# Altium schematic sheet Power.SchDoc(Power)
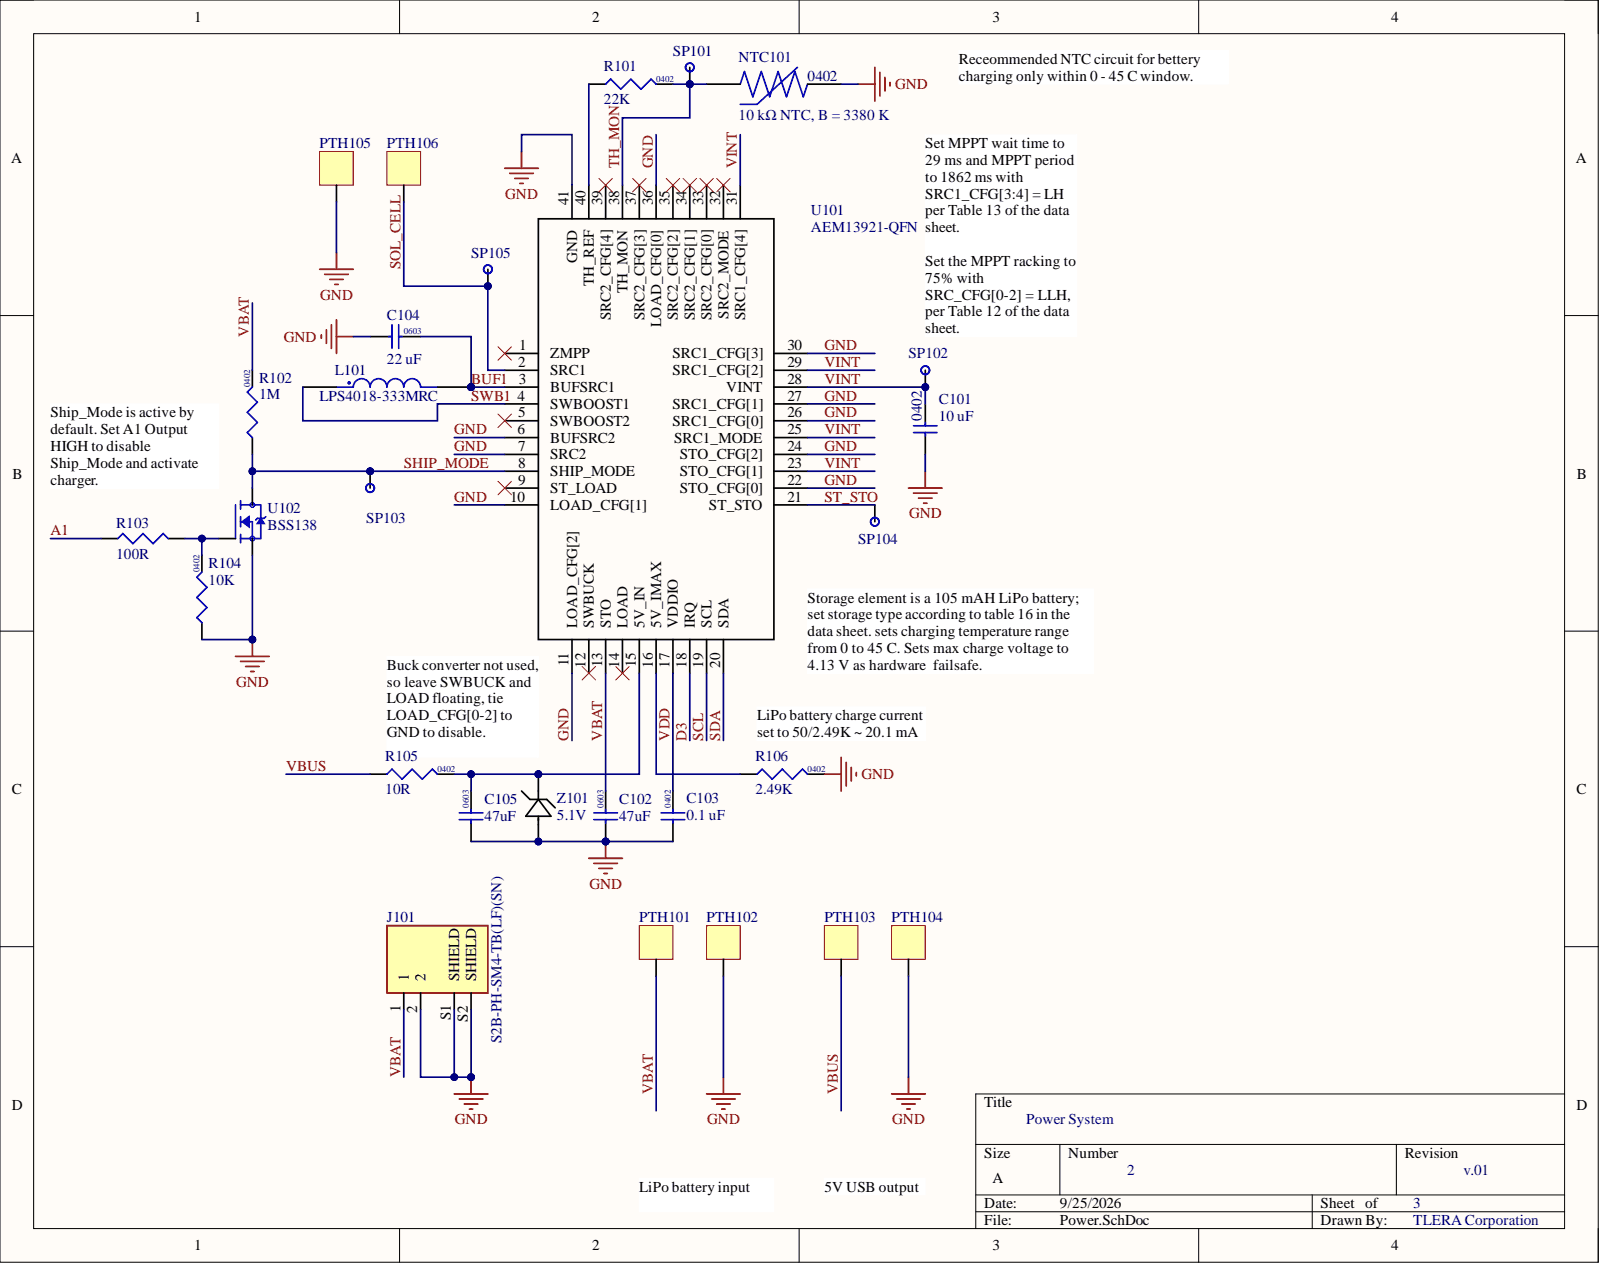
[diagram p0: Power.SchDoc]

## Parts
C101  10 uF  Size Code: 0402
C102  47uF
C103  0.1 uF  Size Code: 0402
C104  22 uF
C105  47uF
J101  S2B-PH-SM4-TB(LF)(SN)
L101  LPS4018-333MRC
NTC101  10 kΩ NTC, B = 3380 K
PTH101  PTH_2mm  (value not drawn)
PTH102  PTH_2mm  (value not drawn)
PTH103  PTH_2mm  (value not drawn)
PTH104  PTH_2mm  (value not drawn)
PTH105  PTH_2mm  (value not drawn)
PTH106  PTH_2mm  (value not drawn)
R101  22K
R102  1M  Size Code: 0402
R103  100R
R104  10K  Size Code: 0402
R105  10R
R106  2.49K
SP101  1 mm Test Pad  (value not drawn)
SP102  1 mm Test Pad  (value not drawn)
SP103  1 mm Test Pad  (value not drawn)
SP104  1 mm Test Pad  (value not drawn)
SP105  1 mm Test Pad  (value not drawn)
U101  AEM13921-QFN
U102  BSS138
Z101  5.1V

## Nets
A1: R103.1
BUF1: C104.2 L101.2 U101.3(BUFSRC1)
D3: U101.18(IRQ)
GND: C101.1 C102.1 C103.1 C104.1 C105.1 J101.2 J101.S1(SHIELD) J101.S2(SHIELD) NTC101.2 PTH102.1 PTH104.1 PTH105.1 R104.1 R106.2 U101.6(BUFSRC2) U101.7(SRC2) U101.10(LOAD_CFG[1]) U101.11(LOAD_CFG[2]) U101.22(STO_CFG[0]) U101.24(STO_CFG[2]) U101.26(SRC1_CFG[0]) U101.27(SRC1_CFG[1]) U101.30(SRC1_CFG[3]) U101.36(LOAD_CFG[0]) U101.41(GND) U102.2 Z101.2
SCL: U101.19(SCL)
SDA: U101.20(SDA)
SHIP_MODE: R102.1 SP103.1 U101.8(SHIP_MODE) U102.3
SOL_CELL: PTH106.1 SP105.1 U101.2(SRC1)
ST_STO: SP104.1 U101.21(ST_STO)
SWB1: L101.1 U101.4(SWBOOST1)
TH_MON: NTC101.1 R101.2 SP101.1 U101.38(TH_MON)
VBAT: C102.2 J101.1 PTH101.1 R102.2 U101.13(STO)
VBUS: PTH103.1 R105.1
VDD: C103.2 U101.17(VDDIO)
VINT: C101.2 SP102.1 U101.23(STO_CFG[1]) U101.25(SRC1_MODE) U101.28(VINT) U101.29(SRC1_CFG[2]) U101.31(SRC1_CFG[4])
NetC105_2: C105.2 R105.2 U101.15(5V_IN) Z101.1
NetR101_1: R101.1 U101.40(TH_REF)
NetR103_2: R103.2 R104.2 U102.1
NetR106_1: R106.1 U101.16(5V_IMAX)

Not connected: U101 1(ZMPP) 5(SWBOOST2) 9(ST_LOAD) 12(SWBUCK) 14(LOAD) 32(SRC2_MODE) 33(SRC2_CFG[0]) 34(SRC2_CFG[1]) 35(SRC2_CFG[2]) 37(SRC2_CFG[3]) 39(SRC2_CFG[4])

## Library data of the parts
C101 = CL05A106MQ5NUNC: 0402 10uF Ceramic Capacitor, Multilayer, Ceramic, 6.3V, 20% +Tol, 20% -Tol, X5R, 15% TC, 10uF, Surface Mount, 0402; Manufacturer: Samsung; Multilayer: Yes; Capacitance: 10uF; Capacitor Type: Ceramic; Category: Ceramic Capacitors; Component Kind: Standard; ComponentLink1Description: https://datasheet.ciiva.com:443/pdfs/VipMasterIC/IC/SEMS/SEMS-S-A0008521219/SEMS-S-A0008521300-1.pdf?src-supplier=IHS+Markit; ComponentLink1URL: https://datasheet.ciiva.com:443/pdfs/VipMasterIC/IC/SEMS/SEMS-S-A0008521219/SEMS-S-A0008521300-1.pdf?src-supplier=IHS+Markit; ComponentLink2Description: https://datasheet.ciiva.com/16437/cl05a106mq5nunc-16437356.pdf?src-supplier=Future+Electronics; ComponentLink2URL: https://datasheet.ciiva.com/16437/cl05a106mq5nunc-16437356.pdf?src-supplier=Future+Electronics; ComponentLink3Description: https://datasheet.ciiva.com/26877/getdatasheetpartid-355289-26877841.pdf?src-supplier=Verical; ComponentLink3URL: https://datasheet.ciiva.com/26877/getdatasheetpartid-355289-26877841.pdf?src-supplier=Verical; Dielectric Material: Ceramic; Height: 0.7mm; JESD-609 Code: e3; Length: 1mm; Library Name: Sensors.SchLib; Manufacturer Part Number: CL05A106MQ5NUNC; Max Operating Temperature: 85°C; Min Operating Temperature: -55°C; Mount: Surface Mount; Negative Tolerance: 20%; Number of Pins: 2; Package Shape: Rectangular; Package Style: SMTMeter; Packaging: Tape and Reel; Positive Tolerance: 20%; Pricing 1: 1=0.26000, 10=0.17600, 50=0.10540, 100=0.08900, 500=0.06086, 1000=0.05384, 2500=0.05150, 5000=0.04682 (USD); Rated DC Voltage (URdc): 6.3V; REACH SVHC: Yes; RoHS: Yes; RoHS Compliant: Yes; Stock 1: 9272723; Supplier 1: Digi-Key; Supplier Part Number 1: 1276-1451-1-ND; Temperature Characteristics Code: X5R; Terminal Finish: Nickel; Termination: Wraparound; Width: 0.5mm
C102 C105 = GRM188R60J476ME15D: 0603 47 µF ±20% 6.3V Ceramic Capacitor X5R 0603 (1608 Metric); Component Kind: Standard; Library Name: Sensors.SchLib; Supplier 1: Avnet; Supplier Part Number 1: GRM188R60J476ME15D
C103 = CL05B104KO5NNNC: 0402 0.1 uF Ceramic Capacitor, Multilayer, Ceramic, 16V, 10% +Tol, 10% -Tol, X7R, 15% TC, 0.1uF, Surface Mount, 0402; Manufacturer: Samsung; Capacitance: 100nF; Multilayer: Yes; Capacitor Type: Ceramic; Category: Ceramic Capacitors; Component Kind: Standard; ComponentLink1Description: https://datasheet.ciiva.com:443/pdfs/VipMasterIC/IC/SEMS/SEMS-S-A0008384515/SEMS-S-A0008872921-1.pdf?src-supplier=IHS+Markit; ComponentLink1URL: https://datasheet.ciiva.com:443/pdfs/VipMasterIC/IC/SEMS/SEMS-S-A0008384515/SEMS-S-A0008872921-1.pdf?src-supplier=IHS+Markit; ComponentLink2Description: https://datasheet.ciiva.com/26920/getdatasheetpartid-359683-26920169.pdf?src-supplier=Verical; ComponentLink2URL: https://datasheet.ciiva.com/26920/getdatasheetpartid-359683-26920169.pdf?src-supplier=Verical; ComponentLink3Description: https://datasheet.ciiva.com/29198/458718-da-01-en-vs-kondensator-0402-29198423.pdf?src-supplier=Conrad; ComponentLink3URL: https://datasheet.ciiva.com/29198/458718-da-01-en-vs-kondensator-0402-29198423.pdf?src-supplier=Conrad; ComponentLink4Description: https://datasheet.ciiva.com/16208/cl05b104kq5nnnc-16208343.pdf?src-supplier=Future+Electronics; ComponentLink4URL: https://datasheet.ciiva.com/16208/cl05b104kq5nnnc-16208343.pdf?src-supplier=Future+Electronics; ComponentLink5Description: https://datasheet.ciiva.com/26309/mlcc-catalogdownload2013-eng-26309003.pdf?src-supplier=Distrelec; ComponentLink5URL: https://datasheet.ciiva.com/26309/mlcc-catalogdownload2013-eng-26309003.pdf?src-supplier=Distrelec; Dielectric Material: Ceramic; Height: 0.55mm; JESD-609 Code: e3; Length: 1mm; Library Name: Sensors.SchLib; Manufacturer Part Number: CL05B104KO5NNNC; Max Operating Temperature: 125°C; Min Operating Temperature: -55°C; Mount: Surface Mount; Negative Tolerance: 10%; Number of Pins: 2; Package Shape: Rectangular; Package Style: SMTMeter; Packaging: Tape and Reel; Positive Tolerance: 10%; Pricing 1: 1=0.10000, 10=0.02600, 50=0.01400, 100=0.01150, 500=0.00820, 1000=0.00644, 2500=0.00586, 5000=0.00539 (USD); Rated DC Voltage (URdc): 16V; REACH SVHC: Yes; RoHS: Yes; RoHS Compliant: Yes; Stock 1: 30141598; Supplier 1: Digi-Key; Supplier Part Number 1: 1276-1001-1-ND; Temperature Characteristics Code: X7R; Terminal Finish: Nickel; Termination: Wraparound; Width: 0.5mm
C104 = GRM188R61A226ME15D: 0603 22 µF ±20% 10V Ceramic Capacitor X5R 0603 (1608 Metric); Component Kind: Standard; Library Name: Sensors.SchLib; Supplier 1: Newark; Supplier Part Number 1: GRM188R61A226ME15D
J101 = S2B-PH-SM4-TB(LF)(SN): Connector Header Surface Mount, Right Angle 2 position 0.079 (2.00mm); MF: JST Sales; Check_prices: https://www.snapeda.com/parts/S2B-PH-SM4-TB(LF)(SN)/JST+Sales+America+Inc./view-part/?ref=eda; Component Kind: Standard; Digi-Key_Part_Number: 455-1749-2-ND; MP: S2B-PH-SM4-TB(LF)(SN); Package: None; Purchase-URL: https://pricing.snapeda.com/search/part/S2B-PH-SM4-TB(LF)(SN)/?ref=eda; SnapEDA_Link: https://www.snapeda.com/parts/S2B-PH-SM4-TB(LF)(SN)/JST+Sales+America+Inc./view-part/?ref=snap; Supplier 1: Future Electronics; Supplier Part Number 1: S2B-PH-SM4-TB(LF)(SN); Library Name: Snoopy.SchLib
L101 = LPS4018-333MRC: 33 ÂµH Shielded Drum Core Inductor 550 mA 420mOhm Max Nonstandard; MF: Coilcraft; Check_prices: https://www.snapeda.com/parts/LPS4018-333MRC/Coilcraft/view-part/?ref=eda; Component Kind: Standard; Library Name: Sensors.SchLib; MP: LPS4018-333MRC; Package: Package; SnapEDA_Link: https://www.snapeda.com/parts/LPS4018-333MRC/Coilcraft/view-part/?ref=snap; Supplier 1: Mouser; Supplier Part Number 1: 994-LPS4018-333MRC
NTC101 = NCP15XH103J03RC: 0402 NTC Thermistor 10k 0402 (1005 Metric); MF: Murata Electronics; Check_prices: https://www.snapeda.com/parts/NCP15XH103J03RC/Murata/view-part/?ref=eda; Component Kind: Standard; Library Name: Sensors.SchLib; MP: NCP15XH103J03RC; Package: 1005 Taiyo Yuden; SnapEDA_Link: https://www.snapeda.com/parts/NCP15XH103J03RC/Murata/view-part/?ref=snap; Supplier 1: Newark; Supplier Part Number 1: NCP15XH103J03RC
R101 = RC0402FR-0722KL: 0402 22 kOhms ±1% 0.063W, 1/16W Chip Resistor 0402 (1005 Metric) Moisture Resistant Thick Film; Component Kind: Standard; Library Name: Sensors.SchLib; Supplier 1: Avnet; Supplier Part Number 1: RC0402FR-0722KL
R102 = CR0402-JW-105GLF: 0402 1M Res Thick Film 0402 1M Ohm 5% 1/16W ±200ppm/°C Molded SMD SMD Paper Tape on Plastic Reel; Manufacturer: Bourns; Package Height: 0.35mm; Package Length: 1mm; Package Width: 0.5mm; Rated Power Dissipation (P): 62.5mW; Rated Temperature: 70°C; Resistance: 1MR; Working Voltage: 50V; Category: Chip SMD Resistors; Component Kind: Standard; ComponentLink1Description: https://datasheet.ciiva.com:443/pdfs/VipMasterIC/IC/BOUR/BOUR-S-A0008953887/BOUR-S-A0008953887-1.pdf?src-supplier=IHS+Markit; ComponentLink1URL: https://datasheet.ciiva.com:443/pdfs/VipMasterIC/IC/BOUR/BOUR-S-A0008953887/BOUR-S-A0008953887-1.pdf?src-supplier=IHS+Markit; ComponentLink2Description: https://datasheet.ciiva.com/18608/cr0402-18608421.pdf?src-supplier=Digi-Key; ComponentLink2URL: https://datasheet.ciiva.com/18608/cr0402-18608421.pdf?src-supplier=Digi-Key; ComponentLink3Description: https://datasheet.ciiva.com/9123/cr0402-9123638.pdf?src-supplier=Avnet; ComponentLink3URL: https://datasheet.ciiva.com/9123/cr0402-9123638.pdf?src-supplier=Avnet; Construction: Chip; JESD-609 Code: e3; Library Name: Sensors.SchLib; Manufacturer Part Number: CR0402-JW-105GLF; Max Operating Temperature: 125°C; Min Operating Temperature: -55°C; Mount: Surface Mount; Number of Pins: 2; Package Shape: Rectangular; Package Style: SMTMeter; Packaging: Tape and Reel; Pricing 1: 1=0.10000, 10=0.01000, 100=0.00290, 1000=0.00128, 2500=0.00111, 5000=0.00092 (USD); Reach SVHC Compliant: No; Resistor Type: FIXED RESISTOR; RoHS: Yes; RoHS Compliant: Yes; Stock 1: 363574; Supplier 1: Digi-Key; Supplier Part Number 1: CR0402-JW-105GLFCT-ND; Technology: RUTHENIUM OXIDE; Temperature Coefficient: 200ppm/°C; Terminal Finish: Nickel; Termination: Wraparound; Tolerance: 5%
R103 = RC0402JR-07100RL: 0402 100R Fixed Resistor, Metal Glaze/thick Film, 0.0625W, 100ohm, 50V, 5% +/-Tol, 100ppm/Cel, Surface Mount, 0402; Manufacturer: Yageo; Additional Feature: LASER TRIMMABLE; Package Height: 0.35mm; Package Length: 1mm; Package Width: 0.5mm; Power: 62.5mW; Rated Temperature: 70°C; Temperature Coefficient: 100ppm/°C; Case/Package: 0402; Category: Chip SMD Resistors; Component Kind: Standard; ComponentLink1Description: https://datasheet.ciiva.com:443/pdfs/VipMasterIC/IC/YAGO/YAGO-S-A0008145938/YAGO-S-A0008145938-1.pdf?src-supplier=IHS+Markit; ComponentLink1URL: https://datasheet.ciiva.com:443/pdfs/VipMasterIC/IC/YAGO/YAGO-S-A0008145938/YAGO-S-A0008145938-1.pdf?src-supplier=IHS+Markit; ComponentLink2Description: https://datasheet.ciiva.com/46394/1018594-46394943.pdf?src-supplier=Element14; ComponentLink2URL: https://datasheet.ciiva.com/46394/1018594-46394943.pdf?src-supplier=Element14; ComponentLink3Description: https://datasheet.ciiva.com/2452/pyu-r-int-thick-7-2452618.pdf?src-supplier=Digi-Key; ComponentLink3URL: https://datasheet.ciiva.com/2452/pyu-r-int-thick-7-2452618.pdf?src-supplier=Digi-Key; ComponentLink4Description: https://datasheet.ciiva.com/2452/pyu-r-int-thick-7-2452619.pdf?src-supplier=Digi-Key; ComponentLink4URL: https://datasheet.ciiva.com/2452/pyu-r-int-thick-7-2452619.pdf?src-supplier=Digi-Key; ComponentLink5Description: https://datasheet.ciiva.com/2452/pyu-r-int-thick-7-2452617.pdf?src-supplier=Digi-Key; ComponentLink5URL: https://datasheet.ciiva.com/2452/pyu-r-int-thick-7-2452617.pdf?src-supplier=Digi-Key; ComponentLink6Description: https://datasheet.ciiva.com/12577/pyu-rc0402-51-rohs-l-6-r-12577725.pdf?src-supplier=Mouser; ComponentLink6URL: https://datasheet.ciiva.com/12577/pyu-rc0402-51-rohs-l-6-r-12577725.pdf?src-supplier=Mouser; ComponentLink7Description: https://datasheet.ciiva.com/7850/1018594-7850135.pdf?src-supplier=Element14; ComponentLink7URL: https://datasheet.ciiva.com/7850/1018594-7850135.pdf?src-supplier=Element14; ComponentLink8Description: https://datasheet.ciiva.com/7850/1018594-7850134.pdf?src-supplier=Element14; ComponentLink8URL: https://datasheet.ciiva.com/7850/1018594-7850134.pdf?src-supplier=Element14; ComponentLink9Description: https://datasheet.ciiva.com/16740/pyu-rc0402-51-rohs-l-6-r-16740924.pdf?src-supplier=Future+Electronics; ComponentLink9URL: https://datasheet.ciiva.com/16740/pyu-rc0402-51-rohs-l-6-r-16740924.pdf?src-supplier=Future+Electronics; Construction: Chip; JESD-609 Code: e3; Manufacturer Part Number: RC0402JR-07100RL; Max Operating Temperature: 155°C; Min Operating Temperature: -55°C; Mounting Technology: Surface Mount; Package Shape: Rectangular; Package Style: SMTMeter; Packaging: Tape and Reel; Pins: 2; Pricing 1: 1=0.10000, 10=0.01200, 100=0.00480, 1000=0.00214, 2500=0.00186, 5000=0.00154 (USD); Reach SVHC Compliant: Yes; Resistor Type: FIXED RESISTOR; RoHS: Yes; RoHS Compliant: Yes; Stock 1: 12367787; Supplier 1: Digi-Key; Supplier Part Number 1: 311-100JRCT-ND; Technology: METAL GLAZE/THICK FILM; Terminal Finish: Nickel; Termination: Wraparound; Tolerance: 5%; Value: 100R; Working Voltage: 50V; Library Name: Ladybug.SchLib
R104 = CR0402-FX-1002GLF: 0402 10K Fixed Resistor, Metal Glaze/thick Film, 0.0625W, 10000ohm, 50V, 1% +/-Tol, 100ppm/Cel, Surface Mount, 0402; Manufacturer: Bourns; Package Height: 0.35mm; Package Length: 1mm; Package Width: 0.5mm; Rated Power Dissipation (P): 62.5mW; Rated Temperature: 70°C; Resistance: 10kR; Temperature Coefficient: 100ppm/°C; Category: Chip SMD Resistors; Component Kind: Standard; ComponentLink1Description: https://datasheet.ciiva.com:443/pdfs/VipMasterIC/IC/BOUR/BOUR-S-A0008953887/BOUR-S-A0008953887-1.pdf?src-supplier=IHS+Markit; ComponentLink1URL: https://datasheet.ciiva.com:443/pdfs/VipMasterIC/IC/BOUR/BOUR-S-A0008953887/BOUR-S-A0008953887-1.pdf?src-supplier=IHS+Markit; ComponentLink2Description: https://datasheet.ciiva.com/30490/0900766b812730ae-30490501.pdf?src-supplier=RSComponents; ComponentLink2URL: https://datasheet.ciiva.com/30490/0900766b812730ae-30490501.pdf?src-supplier=RSComponents; ComponentLink3Description: https://datasheet.ciiva.com/3255/cr0402-3255916.pdf?src-supplier=Avnet; ComponentLink3URL: https://datasheet.ciiva.com/3255/cr0402-3255916.pdf?src-supplier=Avnet; ComponentLink4Description: https://datasheet.ciiva.com/6562/cr0402-6562871.pdf?src-supplier=Digi-Key; ComponentLink4URL: https://datasheet.ciiva.com/6562/cr0402-6562871.pdf?src-supplier=Digi-Key; ComponentLink5Description: https://datasheet.ciiva.com/6562/cr0402-6562873.pdf?src-supplier=Digi-Key; ComponentLink5URL: https://datasheet.ciiva.com/6562/cr0402-6562873.pdf?src-supplier=Digi-Key; ComponentLink6Description: https://datasheet.ciiva.com/6562/cr0402-6562911.pdf?src-supplier=Digi-Key; ComponentLink6URL: https://datasheet.ciiva.com/6562/cr0402-6562911.pdf?src-supplier=Digi-Key; ComponentLink7Description: https://datasheet.ciiva.com/7606/1896412-7606203.pdf?src-supplier=Newark; ComponentLink7URL: https://datasheet.ciiva.com/7606/1896412-7606203.pdf?src-supplier=Newark; ComponentLink8Description: https://datasheet.ciiva.com/22644/659744-22644166.pdf?src-supplier=Element14; ComponentLink8URL: https://datasheet.ciiva.com/22644/659744-22644166.pdf?src-supplier=Element14; ComponentLink9Description: https://datasheet.ciiva.com/22713/659744-22713205.pdf?src-supplier=Element14; ComponentLink9URL: https://datasheet.ciiva.com/22713/659744-22713205.pdf?src-supplier=Element14; ComponentLink10Description: https://datasheet.ciiva.com/30133/cr0402-30133006.pdf?src-supplier=Onlinecomponents.com; ComponentLink10URL: https://datasheet.ciiva.com/30133/cr0402-30133006.pdf?src-supplier=Onlinecomponents.com; Construction: Chip; JESD-609 Code: e3; Library Name: Sensors.SchLib; Manufacturer Part Number: CR0402-FX-1002GLF; Max Operating Temperature: 125°C; Min Operating Temperature: -55°C; Mount: Surface Mount; Number of Pins: 2; Package Shape: Rectangular; Package Style: SMTMeter; Packaging: Tape and Reel; Pricing 1: 1=0.10000, 10=0.01000, 100=0.00350, 1000=0.00158, 2500=0.00138, 5000=0.00114 (USD); REACH SVHC: Yes; Resistor Type: FIXED RESISTOR; RoHS: Yes; RoHS Compliant: Yes; Stock 1: 1486885; Supplier 1: Digi-Key; Supplier Part Number 1: CR0402-FX-1002GLFDKR-ND; Technology: RUTHENIUM OXIDE; Terminal Finish: Nickel; Termination: Wraparound; Tolerance: 1%; Working Voltage: 50V
R105 = RC0402FR-0710RL: 0402 10 Ohms ±1% 0.063W, 1/16W Chip Resistor 0402 (1005 Metric) Moisture Resistant Thick Film; Component Kind: Standard; Library Name: Sensors.SchLib; Supplier 1: Avnet; Supplier Part Number 1: RC0402FR-0710RL
R106 = RC0402FR-072K49L: 0402 2.49 kOhms ±1% 0.063W, 1/16W Chip Resistor 0402 (1005 Metric) Moisture Resistant Thick Film; Component Kind: Standard; Library Name: Sensors.SchLib; Supplier 1: Avnet; Supplier Part Number 1: RC0402FR-072K49L
SP101-SP105 = 1 mm Test Pad: 1 mm diameter solder/test pad; Component Kind: Standard; Library Name: Ladybug.SchLib
U101 = AEM13921-QFN: Ultra Efficient Dual Sources Energy Manager with Ratio/Constant Voltage Regulation, Regulated Buck Output and 5 V Charger, 120 mV, 135 mA; Manufacturer_Name: E-PEAS; Geometry.Height: 0.8mm; Component Kind: Standard; Datasheet Link: https://e-peas.com/documents/AEM13921/DS-AEM13921.pdf; Library Name: Sensors.SchLib; Manufacturer_Part_Number: AEM13921-QFN
U102 = BSS138: Small Signal Field-Effect Transistor, 0.22A I(D), 50V, 1-Element, N-Channel, Silicon, Metal-oxide Semiconductor FET; Manufacturer: ON Semiconductor; Availability: Good; Category: MOSFETs; Check_prices: https://www.snapeda.com/parts/BSS138/ON%20Semiconductor/view-part/4794929/?ref=eda; Component Kind: Standard; Manufacturer Part Number: BSS138; MF: ON Semiconductor; MP: BSS138; Package: SOT-23 ON Semiconductor; Price: None; Pricing 1: 3000=0.06509 (USD); Purchase-URL: https://pricing.snapeda.com/search/part/BSS138/?ref=eda; RoHS: Yes; RoHS Compliant: Yes; SnapEDA_Link: https://www.snapeda.com/parts/BSS138/ON%20Semiconductor/view-part/4794929/?ref=snap; Supplier 1: Digi-Key; Supplier Part Number 1: BSS138TR-ND; Library Name: Ladybug.SchLib
Z101 = GDZ5V1LP3-7: Zener Diode 5.1 V 250 mW ±5% Surface Mount X3-DFN0603-2; Manufacturer_Name: Diodes Inc; Component Kind: Standard; Library Name: Sensors.SchLib; Manufacturer_Part_Number: GDZ5V1LP3-7; Supplier 1: Newark; Supplier Part Number 1: GDZ5V1LP3-7; Copyright: Copyright (C) 2025 Ultra Librarian. All rights reserved.
PTH101-PTH106 = PTH_2mm: Component Kind: Standard; Library Name: Ladybug.SchLib
